# Altium schematic sheet 电源.SchDoc
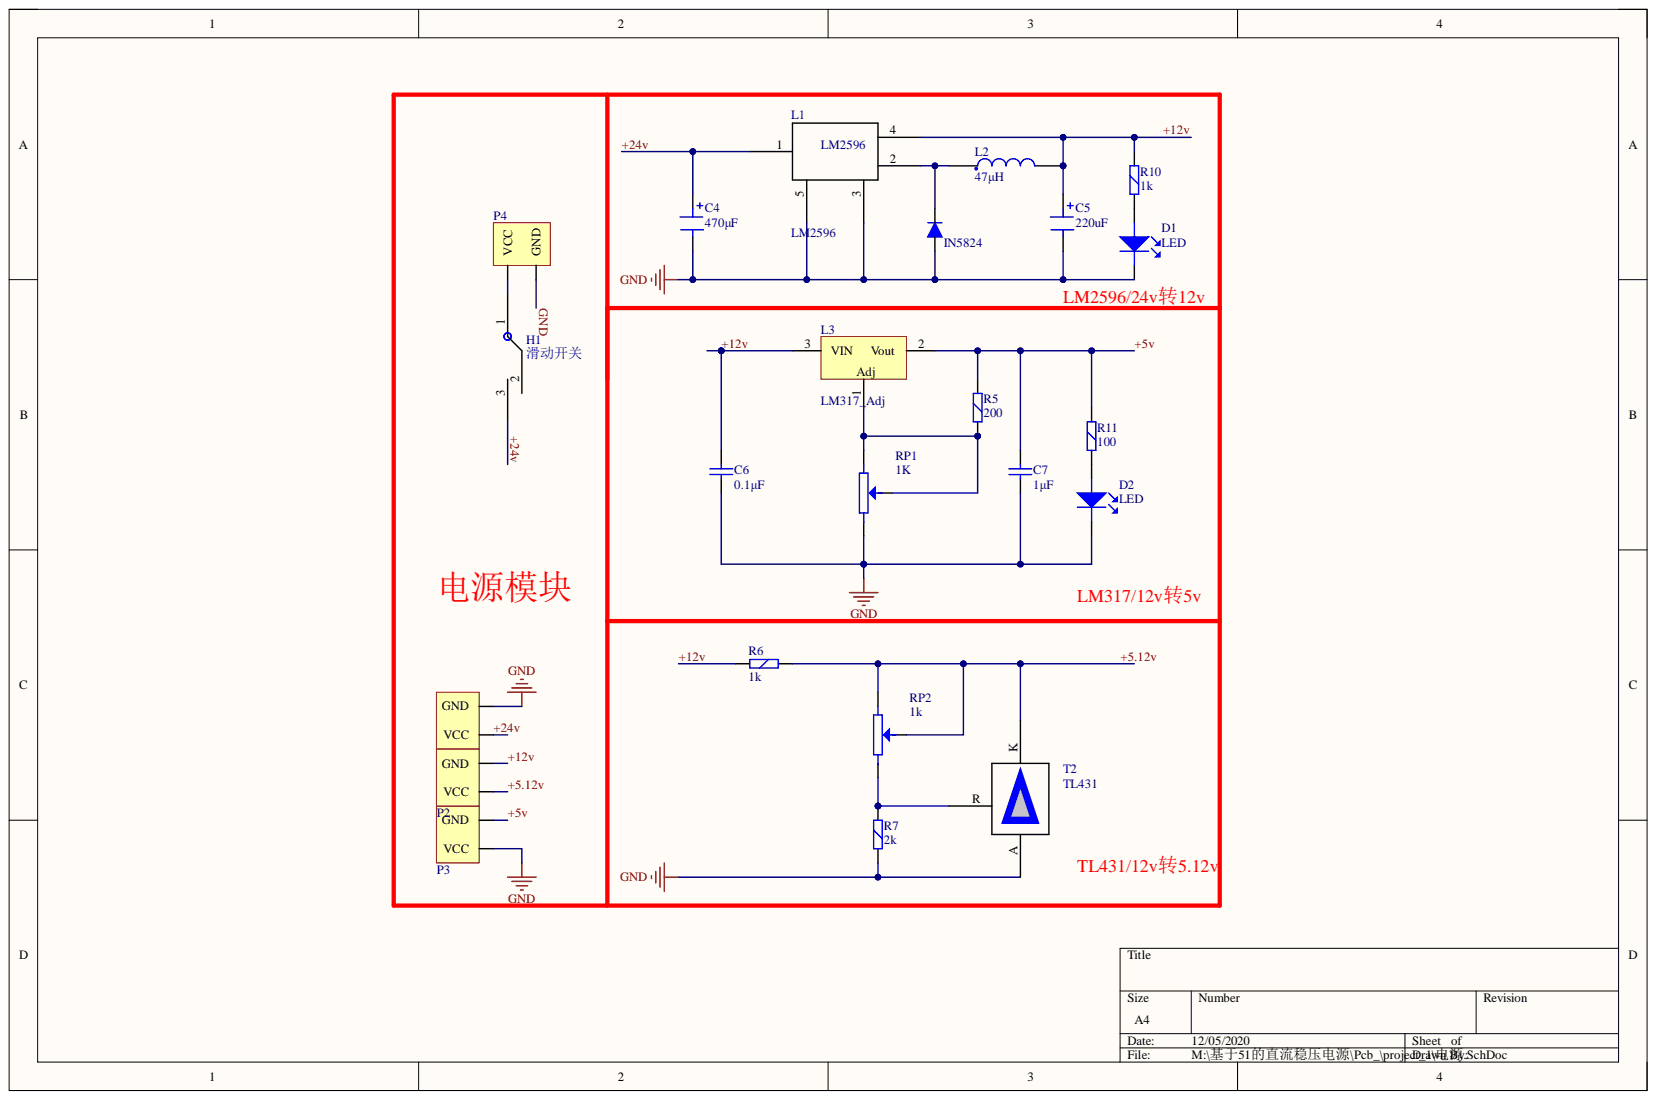
[diagram p0: 电源.SchDoc]

## Parts
C4  470μF  [电解电容_大]
C5  220uF  [电解电容_大]
C6  0.1μF  [电容_瓷片]
C7  1μF  [电容_瓷片]
D1  LED  [LED_G]
D2  LED  [LED_G]
D3  IN5824  [二极管_整流]
H1  滑动开关  [滑动开关]
L1  LM2596  [LM2596]
L2  47μH  [AL0410_V]
L3  LM317_Adj  [7805散热器]
P1  电源端子2P  [端子 - duplicate]  (value not drawn)
P2  电源端子2P  [端子 - duplicate]  (value not drawn)
P3  电源端子2P  [端子 - duplicate]  (value not drawn)
P4  电源端子2P  [端子 - duplicate]  (value not drawn)
R5  200  [1/4WA1]
R6  1k  [1/4WA1]
R7  2k  [1/4WA1]
R10  1k  [1/4WA1]
R11  100  [1/4WA1]
RP1  1K  [3296-103]
RP2  1k  [3296-200]
T2  TL431  [TL431]

## Nets
+5.12v: P2.1 R6.2 RP2.1 RP2.3 T2.K
+5v: C7.2 L3.2 P3.2 R5.1 R11.1
+12v: C5.1 C6.2 L1.4 L2.2 L3.3 P2.2 R6.1 R10.1
+24v: C4.1 H1.3 L1.1 P1.1
GND: C4.2 C5.2 C6.1 C7.1 D1.2 D2.2 D3.1 L1.3 L1.5 P1.2 P3.1 P4.2 R7.2 RP1.2 T2.A
NetD1_1: D1.1 R10.2
NetD2_1: D2.1 R11.2
NetD3_2: D3.2 L1.2 L2.1
NetH1_1: H1.1 P4.1
NetL3_1: L3.1 R5.2 RP1.1 RP1.3
NetR7_1: R7.1 RP2.2 T2.R

Not connected: H1 2

## Library data of the parts
C4 C5 = 电解电容_大: 电解电容; Component Kind: Standard; Library Name: 常用直插器件库.SCHLIB
C6 C7 = 电容_瓷片: 瓷片电容; Component Kind: Standard; Library Name: 常用直插器件库.SCHLIB
D1 D2 = LED: 发光二极管; Component Link1Description: 元件数据连接1，一般是生产厂商的元件描述连接。; Component Link 1URL: 元件厂商的网站连接1，通常是主页地址，如http://www.st.com/; Component Link 2URL: 元件厂商的网站连接2，通常是元件数据说明文件地址，如http://www.fairchildsemi.com/ds/2N%2F2N3904.pdf; ComponentLink2  Description: 元件数据连接2，一般是生产厂商的元件数据库连接。; Kind: General; Latest Revision Note: 最近的应用笔记修订本; Object: Diode; Component Kind: Standard; Datasheet Version: Apr-2016; Latest Revision Date: 13-Apr-2016; Library Name: 二极管.SchLib; Package Description: DIODE0.4及DIODE0.7; Published: 13-Apr-2016; Spice Prefix: D; SubKind: Diode; Type: Diode; Created: 制造厂商; Publisher: Altium Limited
D3 = 二极管_整流: 整流二极管; Component Kind: Standard; Library Name: 常用直插器件库.SCHLIB
L2 = Inductor4: Component Link1Description: 元件数据连接1，一般是生产厂商的元件描述连接。; Component Link 1URL: 元件厂商的网站连接1，通常是主页地址，如http://www.st.com/; Component Link 2URL: 元件厂商的网站连接2，通常是元件数据说明文件地址，如http://www.fairchildsemi.com/ds/2N%2F2N3904.pdf; ComponentLink2  Description: 元件数据连接2，一般是生产厂商的元件数据库连接。; Kind: General; Latest Revision Note: 最近的应用笔记修订本; Component Kind: Standard; Datasheet Version: Apr-2016; Latest Revision Date: 13-Apr-2016; LatestRevisionDate: 13-Apr-2016; LatestRevisionNote: Re-released for DXP Platform.; Library Name: 电感.SchLib; Object: Inductor; Package Description: 封装描述; PackageReference: 425; Published: 13-Apr-2016; Spice Prefix: L; SubKind: Inductor; Created: 制造厂商; Publisher: Altium Limited
L3 = LM317: 可调直流稳压芯片; Component Kind: Standard; Library Name: 常用直插器件库.SCHLIB
P1-P4 = 电源端子2P: 电源端子; : 电源端子2P; Component Kind: Standard; Library Name: 常用直插器件库.SCHLIB
R5-R7 R10 R11 = Res_3: 1/4W电阻器; Component Link1Description: 元件数据连接1，一般是生产厂商的元件描述连接。; Component Link 1URL: 元件厂商的网站连接1，通常是主页地址，如http://www.st.com/; Component Link 2URL: 元件厂商的网站连接2，通常是元件数据说明文件地址，如http://www.fairchildsemi.com/ds/2N%2F2N3904.pdf; ComponentLink2  Description: 元件数据连接2，一般是生产厂商的元件数据库连接。; Kind: General; Latest Revision Note: 最近的应用笔记修订本; Object: Resistor; Value: 10K; Component Kind: Standard; Datasheet Version: Apr-2016; Latest Revision Date: 13-Apr-2016; LatestRevisionDate: 13-Apr-2016; Library Name: 电阻.SchLib; Package Description: AXIAL0.3-AXIA1.0; Package Reference: AXIAL; PackageVersion: Apr-2016; Published: 13-Apr-2016; Spice Prefix: R; SubKind: Resistor; Type: Resistor; Created: 制造厂商; Publisher: Altium Limited
RP1 RP2 = RESVR: 滑动触点电位器; Component Link1Description: 元件数据连接1，一般是生产厂商的元件描述连接。; Component Link 1URL: 元件厂商的网站连接1，通常是主页地址，如http://www.st.com/; Component Link 2URL: 元件厂商的网站连接2，通常是元件数据说明文件地址，如http://www.fairchildsemi.com/ds/2N%2F2N3904.pdf; ComponentLink2  Description: 元件数据连接2，一般是生产厂商的元件数据库连接。; Kind: General; Latest Revision Note: 最近的应用笔记修订本; Object: Resistor; Value: 10K; Component Kind: Standard; Datasheet Version: Apr-2016; Latest Revision Date: 13-Apr-2016; LatestRevisionDate: 13-Apr-2016; Library Name: 电阻.SchLib; Package Description: AXIAL0.3-AXIA1.0; Package Reference: AXIAL; PackageVersion: Apr-2016; Published: 13-Apr-2016; Spice Prefix: R; SubKind: Resistor; Type: Resistor; Created: 制造厂商; Publisher: Altium Limited
H1 = 滑动开关: Component Kind: Standard; Library Name: 数控直流电源.SchLib
L1 = LM2596: Component Kind: Standard; Library Name: 数控直流电源.SchLib
T2 = TL431: Component Kind: Standard; Library Name: 数控直流电源.SchLib
